# Altium schematic sheet SH2 - MICROCONTROLLER.SchDoc
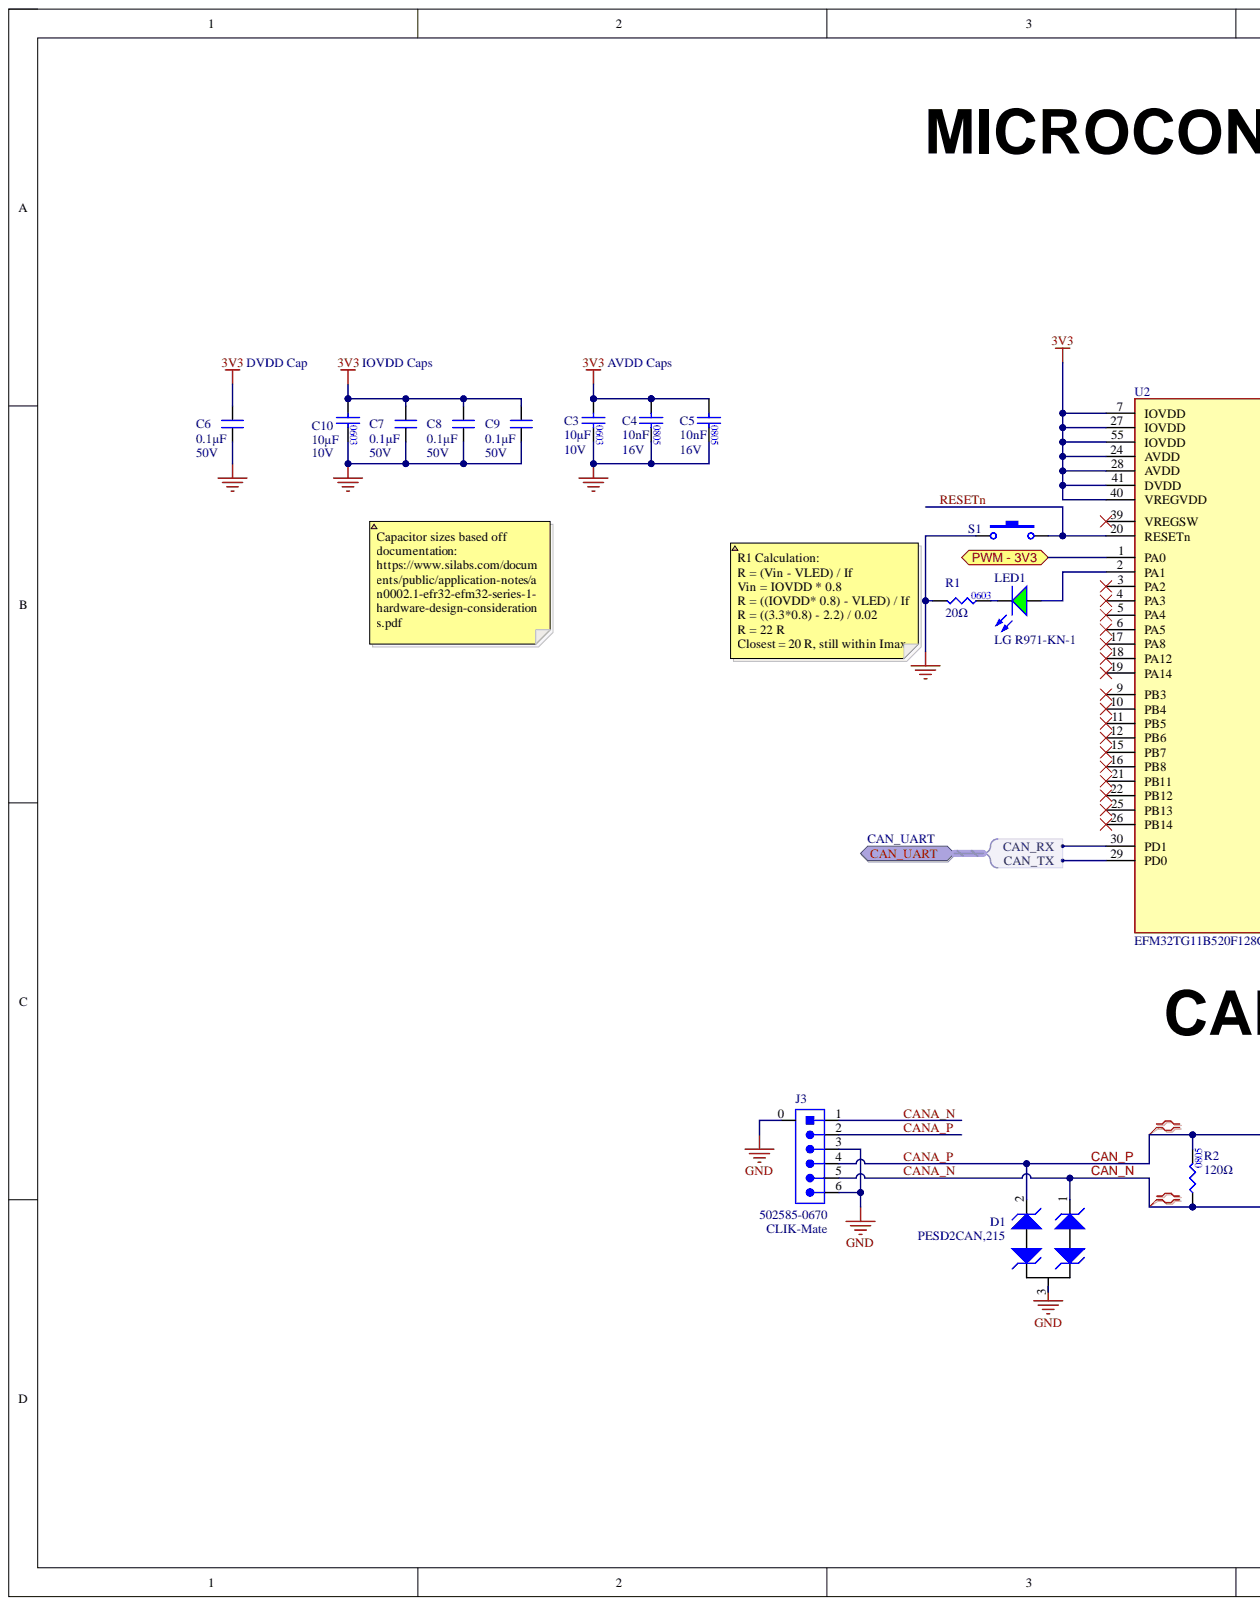
[diagram p0: SH2 - MICROCONTROLLER.SchDoc, left]
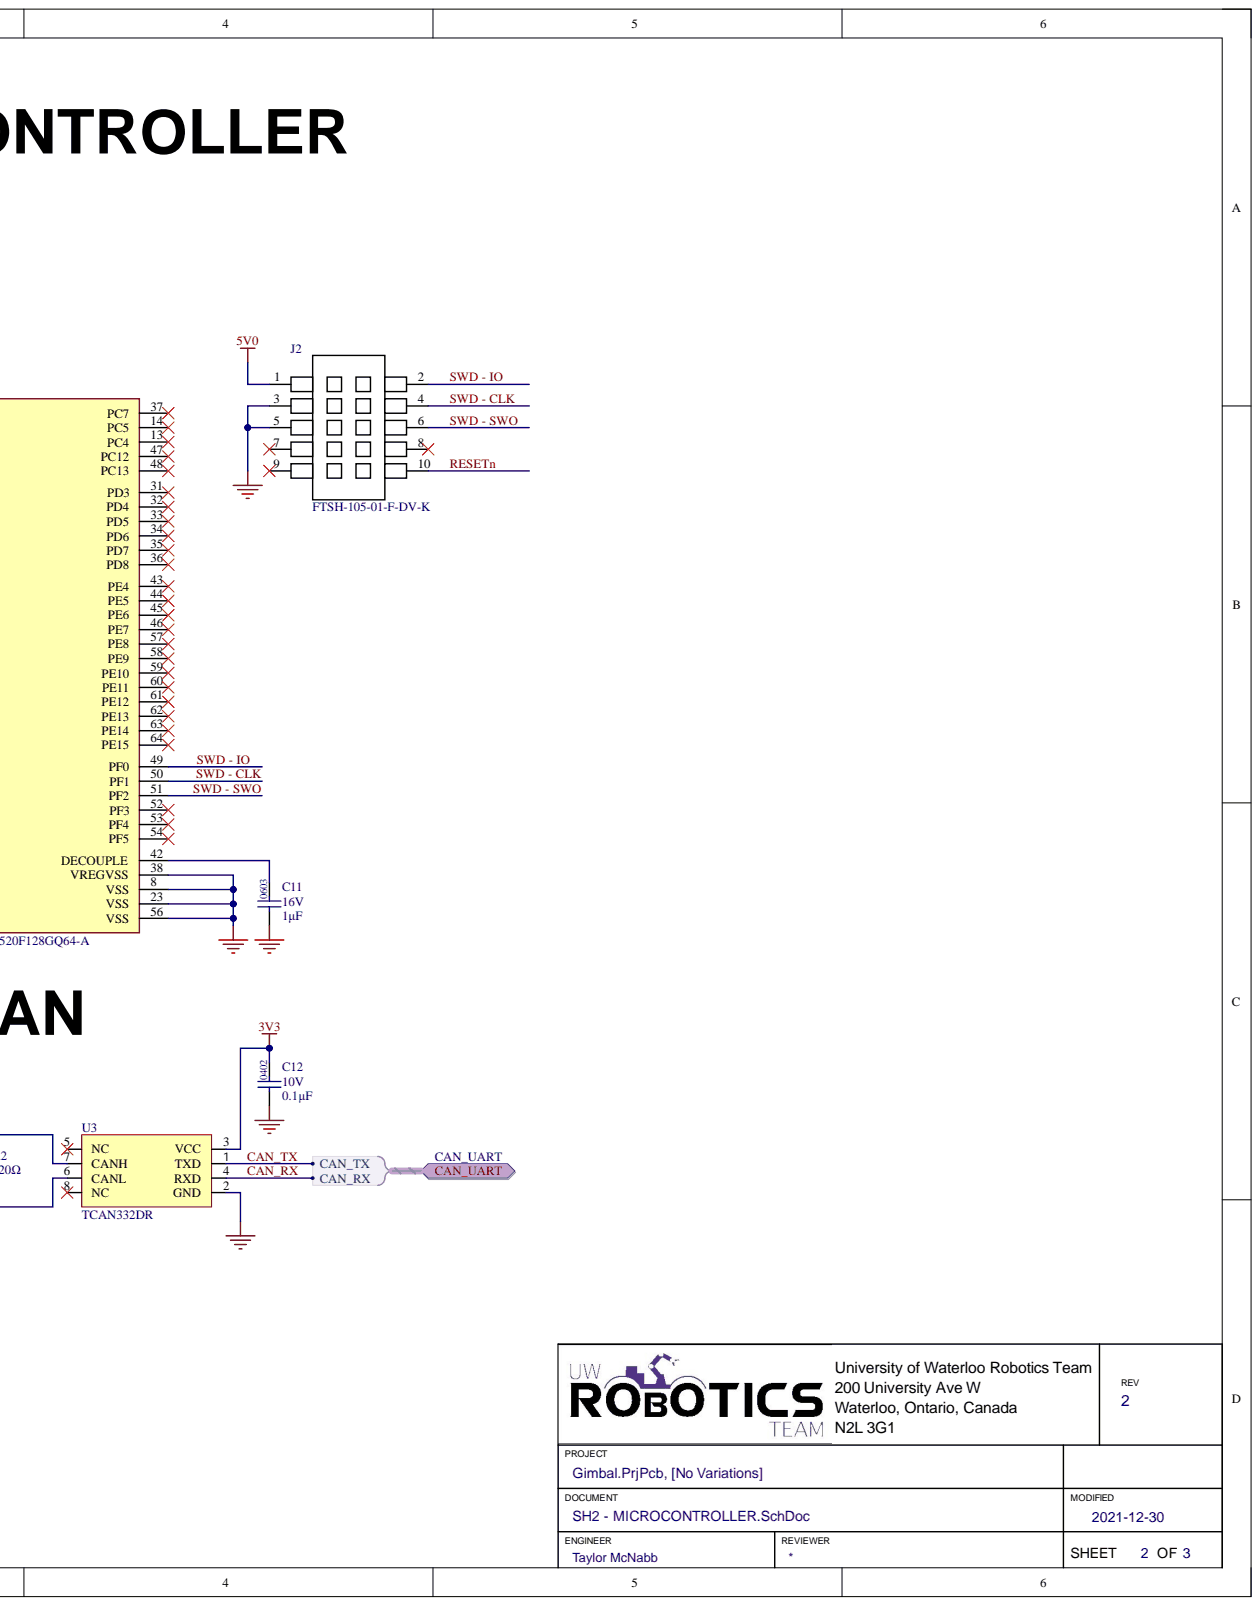
[diagram p1: SH2 - MICROCONTROLLER.SchDoc, right]

## Parts
C3  value 10μF  Voltage Rating: 10V  [CAP0603]  (hidden comment: CAP_10uF_10V_0603)
C4  value 10nF  Voltage Rating: 16V  [CAP2012]  (hidden comment: CAP_10nF_16V_0805)
C5  value 10nF  Voltage Rating: 16V  [CAP2012]  (hidden comment: CAP_10nF_16V_0805)
C6  value 0.1μF  Voltage Rating: 50V  [CAP0603]  (hidden comment: CAP_0.1uF_50V_0603)
C7  value 0.1μF  Voltage Rating: 50V  [CAP0603]  (hidden comment: CAP_0.1uF_50V_0603)
C8  value 0.1μF  Voltage Rating: 50V  [CAP0603]  (hidden comment: CAP_0.1uF_50V_0603)
C9  value 0.1μF  Voltage Rating: 50V  [CAP0603]  (hidden comment: CAP_0.1uF_50V_0603)
C10  value 10μF  Voltage Rating: 10V  [CAP0603]  (hidden comment: CAP_10uF_10V_0603)
C11  value 1μF  Package: 0603  Voltage Rating: 16V  [CAP0603]  (hidden comment: CAP_1uF_16V_0603)
C12  value 0.1µF  Package/Footprint: 0402  Voltage Rating: 10V  [CAP0402]  (hidden comment: CAP_0.1uF_10V_0402)
D1  Manufacturer Part Number 1: PESD2CAN,215  [TVS_DIODE_CAN]  (hidden comment: TVS_DIODE_CAN)
J2  Manufacturer Part Number 1: FTSH-105-01-F-DV-K  [FTSH-105-01-F-DV-K-P-TR]  (hidden comment: CON_PROGRAMMING)
J3  Family: CLIK-Mate  Manufactor Number: 502585-0670  [MOLEX-5025850670]  (hidden comment: CON_6M_SM_RA_1.5mm)
LED1  Manufacturer Part Number 1: LG R971-KN-1  [LED_0805_GREEN]  (hidden comment: LED_GREEN)
R1  value 20Ω  Package: 0603  [RES0603]  (hidden comment: RES_20Ω_0603_5%)
R2  value 120Ω  Package/Footprint: 0805  [RES0805]  (hidden comment: RES_120Ω_0805_1%)
S1  SW_SPST_NO  [B3U-1000P]  (value not drawn)
U2  EFM32TG11B520F128GQ64-A
U3  IC_CAN_TRANSCEIVER_1MBPS  [TCAN332DR]  (value not drawn)

## Ports
CAN_UART, CAN_UART.CAN_RX, CAN_UART.CAN_TX, PWM - 3V3

## Nets
3V3: C3.1 C4.1 C5.1 C6.2 C7.2 C8.2 C9.2 C10.1 C12.2 U2.7(IOVDD) U2.24(AVDD) U2.27(IOVDD) U2.28(AVDD) U2.40(VREGVDD) U2.41(DVDD) U2.55(IOVDD) U3.3(VCC)
5V0: J2.1
GND: C3.2 C4.2 C5.2 C6.1 C7.1 C8.1 C9.1 C10.2 C11.1 C12.1 D1.3 J2.3 J2.5 J3.0 J3.3 J3.6 R1.1 S1.1 U2.8(VSS) U2.23(VSS) U2.38(VREGVSS) U2.56(VSS) U3.2(GND)
CAN_N: D1.1 J3.1 J3.5 R2.1 U3.6(CANL)
CAN_P: D1.2 J3.2 J3.4 R2.2 U3.7(CANH)
CAN_RX: U2.30(PD1) U3.4(RXD) ; port CAN_UART
CAN_TX: U2.29(PD0) U3.1(TXD) ; port CAN_UART
PWM - 3V3: U2.1(PA0) ; port PWM - 3V3
RESETn: J2.10 S1.2 U2.20(RESETn)
SWD - CLK: J2.4 U2.50(PF1)
SWD - IO: J2.2 U2.49(PF0)
SWD - SWO: J2.6 U2.51(PF2)
NetC11_2: C11.2 U2.42(DECOUPLE)
NetLED1_1: LED1.1 U2.2(PA1)
NetLED1_2: LED1.2 R1.2

Not connected: J2 7 8 9; U2 3(PA2) 4(PA3) 5(PA4) 6(PA5) 9(PB3) 10(PB4) 11(PB5) 12(PB6) 13(PC4) 14(PC5) 15(PB7) 16(PB8) 17(PA8) 18(PA12) 19(PA14) 21(PB11) 22(PB12) 25(PB13) 26(PB14) 31(PD3) 32(PD4) 33(PD5) 34(PD6) 35(PD7) 36(PD8) 37(PC7) 39(VREGSW) 43(PE4) 44(PE5) 45(PE6) 46(PE7) 47(PC12) 48(PC13) 52(PF3) 53(PF4) 54(PF5) 57(PE8) 58(PE9) 59(PE10) 60(PE11) 61(PE12) 62(PE13) 63(PE14) 64(PE15); U3 5(NC) 8(NC)

## Library data of the parts
C3 C10 = CAP_10uF_10V_0603: CAP CER 10UF 10V X5R 0603; Manufacturer 1: Yageo; Manufacturer Part Number 1: CC0603MRX5R6BB106; Component Kind: Standard; Dielectric: X5R; Supplier 1: Digi-Key; Supplier Part Number 1: 311-1817-1-ND; Library Name: UWRT_Schematic_Library_2020.SchLib
C4 C5 = CAP_10nF_16V_0805: CAP CER 10000PF 16V X7R 0805; Manufacturer 1: Yageo; Manufacturer Part Number 1: AC0805KRX7R7BB103; Component Kind: Standard; Supplier 1: Digi-Key; Supplier Part Number 1: 311-3161-1-ND; Library Name: UWRT_Schematic_Library_2020.SchLib
C6-C9 = CAP_0.1uF_50V_0603: CAP CER 0.1UF 50V X7R 0603; Manufacturer 1: Yageo; Manufacturer Part Number 1: CC0603KRX7R9BB104; Package/Footprint: 0603; Component Kind: Standard; Dielectric: X7R; Mounting Type: SMD; Supplier 1: Digi-Key; Supplier Part Number 1: 311-1344-1-ND; Temperature Coefficient: X7R; Library Name: UWRT_Schematic_Library_2020.SchLib
C11 = CAP_1uF_16V_0603: CAP CER 1UF 16V Y5V 0603; Manufacturer 1: Yageo; Manufacturer Part Number 1: CC0603ZRY5V7BB105; Component Kind: Standard; Dielectric: Y5V; Mounting Type: SMD; Supplier 1: Digi-Key; Supplier Part Number 1: 311-1372-1-ND; Library Name: UWRT_Schematic_Library_2020.SchLib
C12 = CAP_0.1uF_10V_0402: CAP CER 0.1UF 10V X7R 0402; Manufacturer 1: Murata Electronics; Manufacturer Part Number 1: GRM155R71A104KA01D; Component Kind: Standard; Supplier 1: Digi-Key; Supplier Part Number 1: 490-6321-1-ND; Library Name: UWRT_Schematic_Library_2020.SchLib
D1 = TVS_DIODE_CAN: TVS DIODE 24V 41V SOT323-3; Manufacturer 1: Nexperia USA Inc.; Component Kind: Standard; Mounting Type: Surface Mount; Package/Footprint: SOT323-3; Supplier 1: Digi-Key; Supplier Part Number 1: 1727-3891-1-ND; Library Name: UWRT_Schematic_Library_2020.SchLib
J2 = CON_PROGRAMMING: CONN HEADER SMD 10POS 1.27MM; Manufacturer 1: Samtec; Component Kind: Standard; Mounting Type: SMD; Pitch: 1.27mm; Supplier 1: Digi-Key; Supplier Part Number 1: SAM8796-ND; Library Name: UWRT_Schematic_Library_2020.SchLib
J3 = CON_6M_SM_RA_1.5mm: CONN HEADER SMD RIGHT ANGLE 1.5 MM PITCH; Manufacturer: Molex; Component Kind: Standard; Mounting Type: SMD; Package/Footprint: SMD; Supplier 1: Digi-Key; Supplier Part Number 1: WM12832CT-ND; Library Name: UWRT_Schematic_Library_2020.SchLib
LED1 = LED_GREEN: LED GREEN DIFFUSED 0805 SMD; Manufacturer 1: OSRAM; Forward Voltage Drop: 2.2V; Package/Footprint: 0805; Component Kind: Standard; Mounting Type: SMD; Supplier 1: Digi-Key; Supplier Part Number 1: 475-1410-1-ND; Library Name: UWRT_Schematic_Library_2020.SchLib
R1 = RES_20Ω_0603_5%: RES 20 OHM 5% 1/10W 0603; Manufacturer 1: Yageo; Manufacturer Part Number 1: RC0603JR-0720RL; Component Kind: Standard; Mounting Type: SMD; Supplier 1: Digi-Key; Supplier Part Number 1: 311-20GRCT-ND; Library Name: UWRT_Schematic_Library_2020.SchLib
R2 = RES_120Ω_0805_1%: RES 120 OHM 1% 1/8W 0805; Manufacturer 1: Vishay; Manufacturer Part Number 1: CRCW0805120RFKEA; Component Kind: Standard; LCSC Part #: C17437; Mounting Type: SMD; Supplier 1: Digi-Key; Supplier Part Number 1: 541-120CCT-ND; Library Name: UWRT_Schematic_Library_2020.SchLib
S1 = SW_SPST_NO: SMT 3.0 X 2.6 MM TACT SWITCH WIT; Manufacturer 1: Würth Elektronik; Manufacturer Part Number 1: 435151014824; Component Kind: Standard; Mounting Type: SMD; Supplier 1: Digi-Key; Supplier Part Number 1: 732-435151014824CT-ND; Library Name: UWRT_Schematic_Library_2020.SchLib
U2 = EFM32TG11B520F128GQ64-A: EFM32 Tiny Gecko Series 1 MCUs 32-bit ARM® Cortex®-M0+; Manufacturer: Silicon Labs; Manufacturer Part Number: EFM32TG11B520F128GQ64-AR; Core Architecture: ARM; Frequency: 48MHz; Interface: I2C,SPI,IrDA,UART,USART; Memory Size: 128KB; Memory Type: FLASH; Number of I/Os: 50; Oscillator Type: Internal; Peripherals: Brown-out Detect/Reset,POR,PWM,WDT,DMA,LCD; Case/Package: TQFP; Component Kind: Standard; ComponentLink1Description: https://www.silabs.com/documents/public/data-sheets/efm32tg11-datasheet.pdf?src-supplier=Digi-Key; ComponentLink1URL: https://www.silabs.com/documents/public/data-sheets/efm32tg11-datasheet.pdf?src-supplier=Digi-Key; ComponentLink2Description: Capacitor Sizing Guide; ComponentLink2URL: https://www.silabs.com/documents/public/application-notes/an0002.1-efr32-efm32-series-1-hardware-design-considerations.pdf; Max Operating Temperature: 85°C; Min Operating Temperature: -40°C; Packaging: Tape and Reel; Pricing 1: 1000=2.05659 (USD); RoHS: Yes; Supplier 1: Digi-Key; Supplier Part Number 1: EFM32TG11B520F128GQ64-AR-ND; Library Name: UWRT_Schematic_Library_2020.SchLib
U3 = IC_CAN_TRANSCEIVER_1MBPS: IC TXRX CAN 1MBPS 8SOIC; Manufacturer 1: Texas Instruments; Package/Footprint: 8-SOIC; Receiver Hysteresis: 120 mV; Voltage - Supply: 3V ~ 3.6V; Component Kind: Standard; ComponentLink1Description: New link; Data Rate: 1Mbps; Manufacturer Part Number 1: TCAN332DR; Mounting Type: Surface Mount; Operating Temperature: -40°C ~ 125°C; Supplier 1: Digi-Key; Supplier Part Number 1: 296-43711-1-ND; Library Name: UWRT_Schematic_Library_2020.SchLib
